# Altium schematic sheet SUN_STUDY.SchDoc
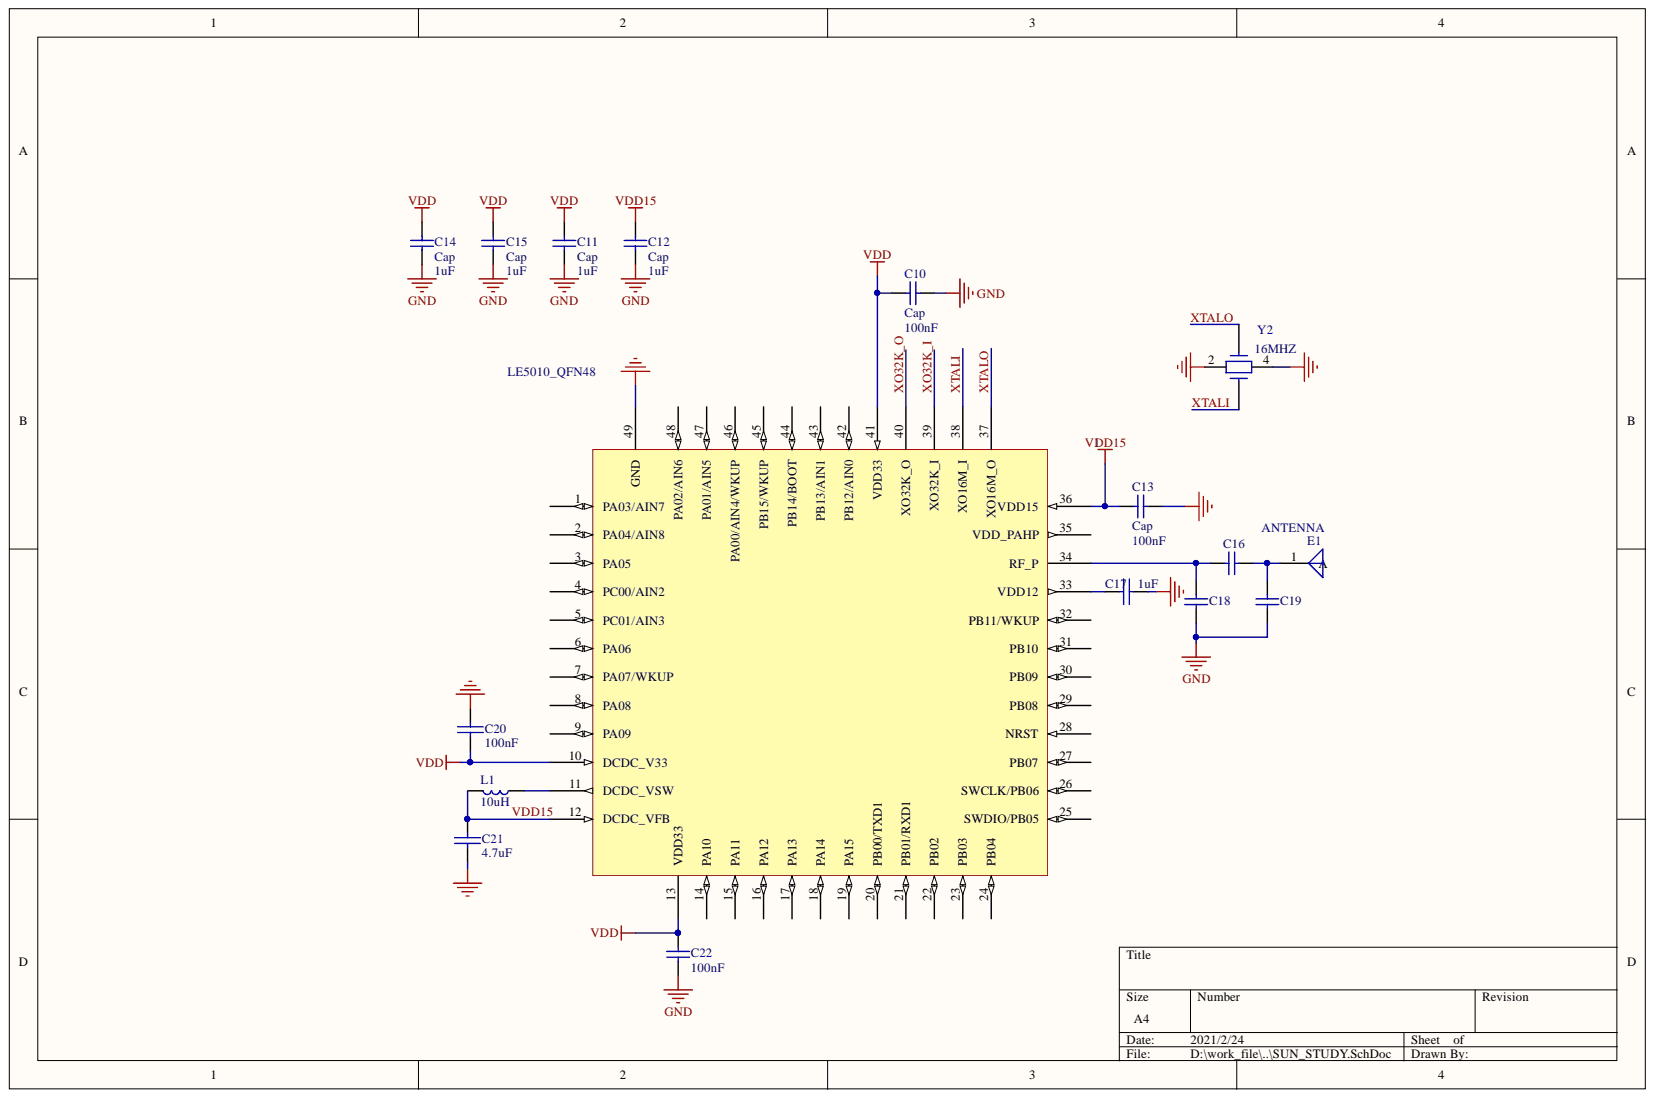
[diagram p0: SUN_STUDY.SchDoc]

## Parts
1  LE5010_QFN48  [QFN-64_L9.0-W9.0-P0.50-BL-EP7.2]
C10  Cap  value 100nF  [C 0603_L]
C11  Cap  value 1uF  [C 0603_L]
C12  Cap  value 1uF  [C 0603_L]
C13  Cap  value 100nF  [C 0603_L]
C14  Cap  value 1uF  [C 0603_L]
C15  Cap  value 1uF  [C 0603_L]
C16  Cap  [C 0603_L]  (value not drawn)
C17  1uF  [C0201]
C18  Cap  [C 0603_L]  (value not drawn)
C19  Cap  [C 0603_L]  (value not drawn)
C20  100nF  [C0201]
C21  4.7uF  [0402]
C22  100nF  [C 0603_L]
E1  ANTENNA  [ANT1(TLSR82XX)]
L1  10uH  [J1-0603]
Y2  16MHZ  [TXL]

## Nets
GND: 1.49(GND) C10.1 C11.1 C12.1 C13.1 C14.1 C15.1 C17.1 C18.1 C19.1 C20.2 C21.1 C22.1 Y2.2 Y2.4
VDD: 1.10(DCDC_V33) 1.13(VDD33) 1.41(VDD33) C10.2 C11.2 C14.2 C15.2 C20.1 C22.2
VDD15: 1.12(DCDC_VFB) 1.36(VDD15) C12.2 C13.2 C21.2 L1.1
XO32K_I: 1.39(XO32K_I)
XO32K_O: 1.40(XO32K_O)
XTALI: 1.38(XO16M_I) Y2.3
XTALO: 1.37(XO16M_O) Y2.1
Net1_11: 1.11(DCDC_VSW) L1.2
Net1_33: 1.33(VDD12) C17.2
Net1_34: 1.34(RF_P) C16.1 C18.2
NetC16_2: C16.2 C19.2 E1.1

Not connected: 1 1(PA03/AIN7) 2(PA04/AIN8) 3(PA05) 4(PC00/AIN2) 5(PC01/AIN3) 6(PA06) 7(PA07/WKUP) 8(PA08) 9(PA09) 14(PA10) 15(PA11) 16(PA12) 17(PA13) 18(PA14) 19(PA15) 20(PB00/TXD1) 21(PB01/RXD1) 22(PB02) 23(PB03) 24(PB04) 25(SWDIO/PB05) 26(SWCLK/PB06) 27(PB07) 28(NRST) 29(PB08) 30(PB09) 31(PB10) 32(PB11/WKUP) 35(VDD_PAHP) 42(PB12/AIN0) 43(PB13/AIN1) 44(PB14/BOOT) 45(PB15/WKUP) 46(PA00/AIN4/WKUP) 47(PA01/AIN5) 48(PA02/AIN6)

## Library data of the parts
C10-C15 C22 = Cap: Capacitor; Component Kind: Standard; LatestRevisionDate: 17-Jul-2002; LatestRevisionNote: Re-released for DXP Platform.; Library Name: Miscellaneous Devices.IntLib; PackageDescription: Radial Cap, Thru-Hole; 2 Leads; 0.3 in Pin Spacing; PackageReference: RAD-0.3; Published: 8-Jun-2000; Signal Integrity: Cap; Simulation: CAP; Publisher: Altium Limited
C16 C18 C19 = Cap: Capacitor; Value: 100pF; Component Kind: Standard; LatestRevisionDate: 17-Jul-2002; LatestRevisionNote: Re-released for DXP Platform.; Library Name: Miscellaneous Devices.IntLib; PackageDescription: Radial Cap, Thru-Hole; 2 Leads; 0.3 in Pin Spacing; PackageReference: RAD-0.3; Published: 8-Jun-2000; Signal Integrity: Cap; Simulation: CAP; Publisher: Altium Limited
C17 C20 = CAP: Capacitor; Component Kind: Standard
C21 = CAP: Component Kind: Standard; Library Name: 元件库.SchLib
E1 = ANTENNA: Generic Antenna; Component Kind: Standard
L1 = Inductance: Component Kind: Standard; Library Name: SOC.SchLib
Y2 = CRYSTAL: Component Kind: Standard; Description: Crystal
1 = LE5010_QFN48: Component Kind: Standard; Library Name: QFN48_LIB.SCHLIB
